# Altium schematic sheet Top_schema.SchDoc
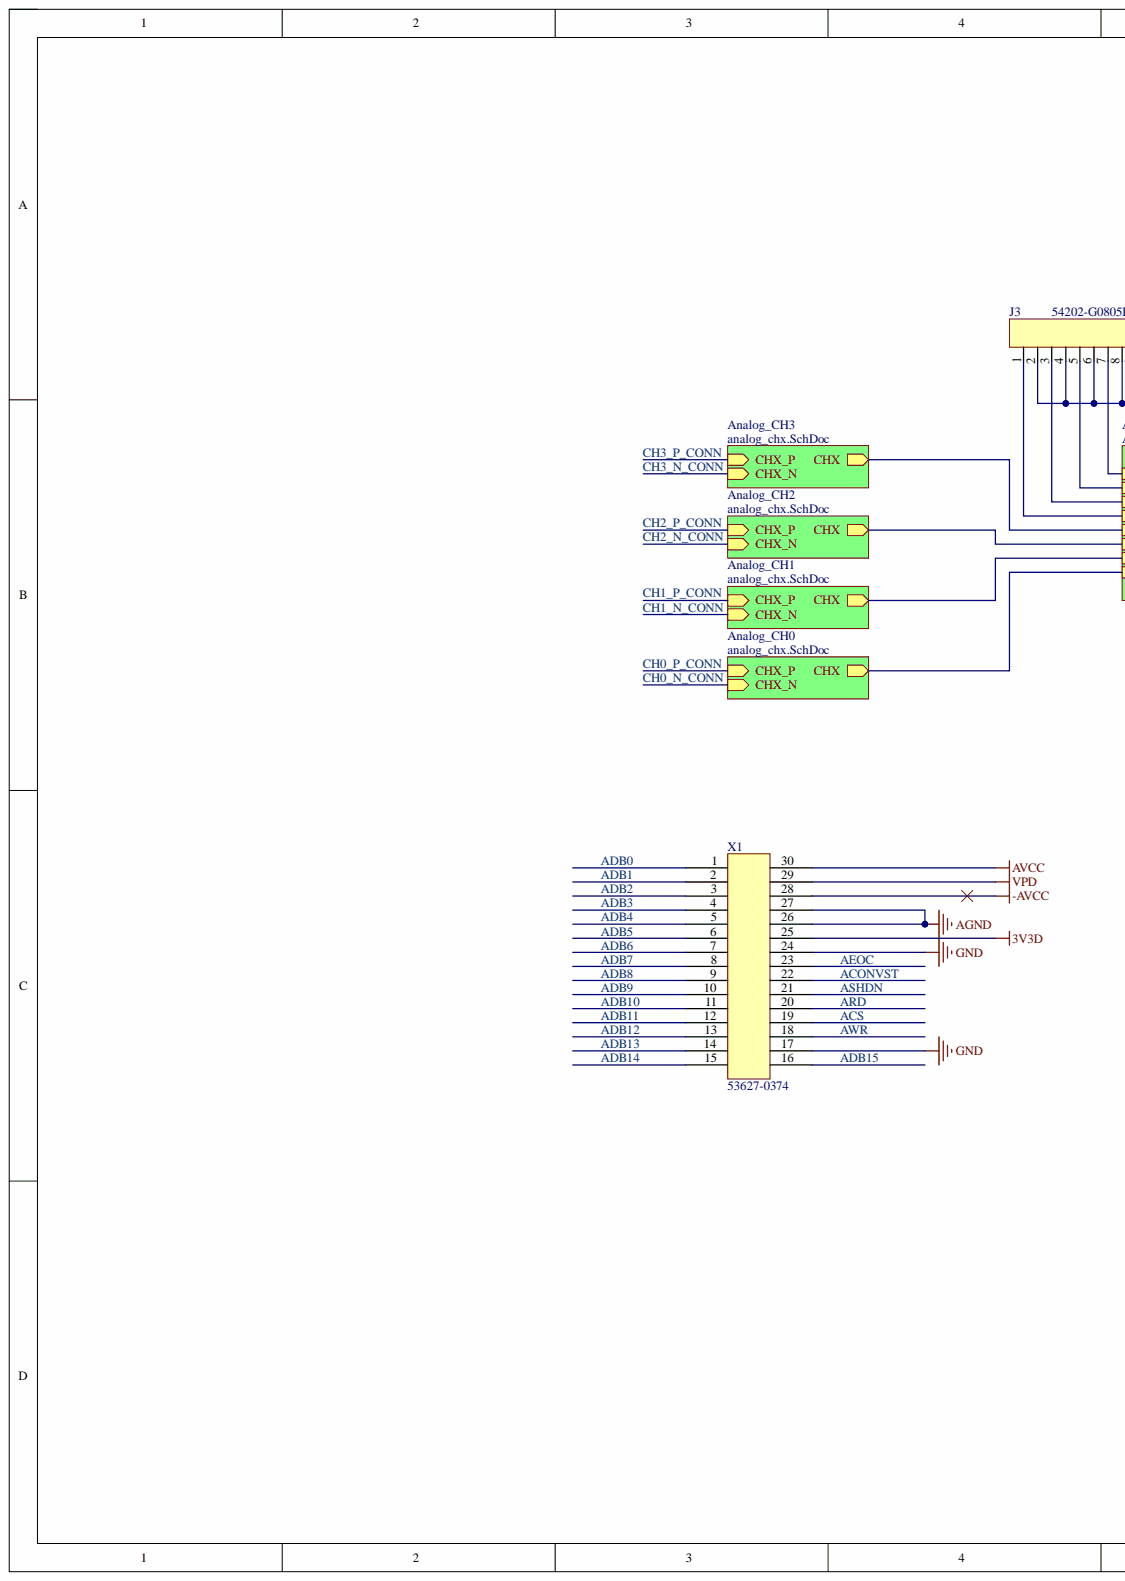
[diagram p0: Top_schema.SchDoc, left]
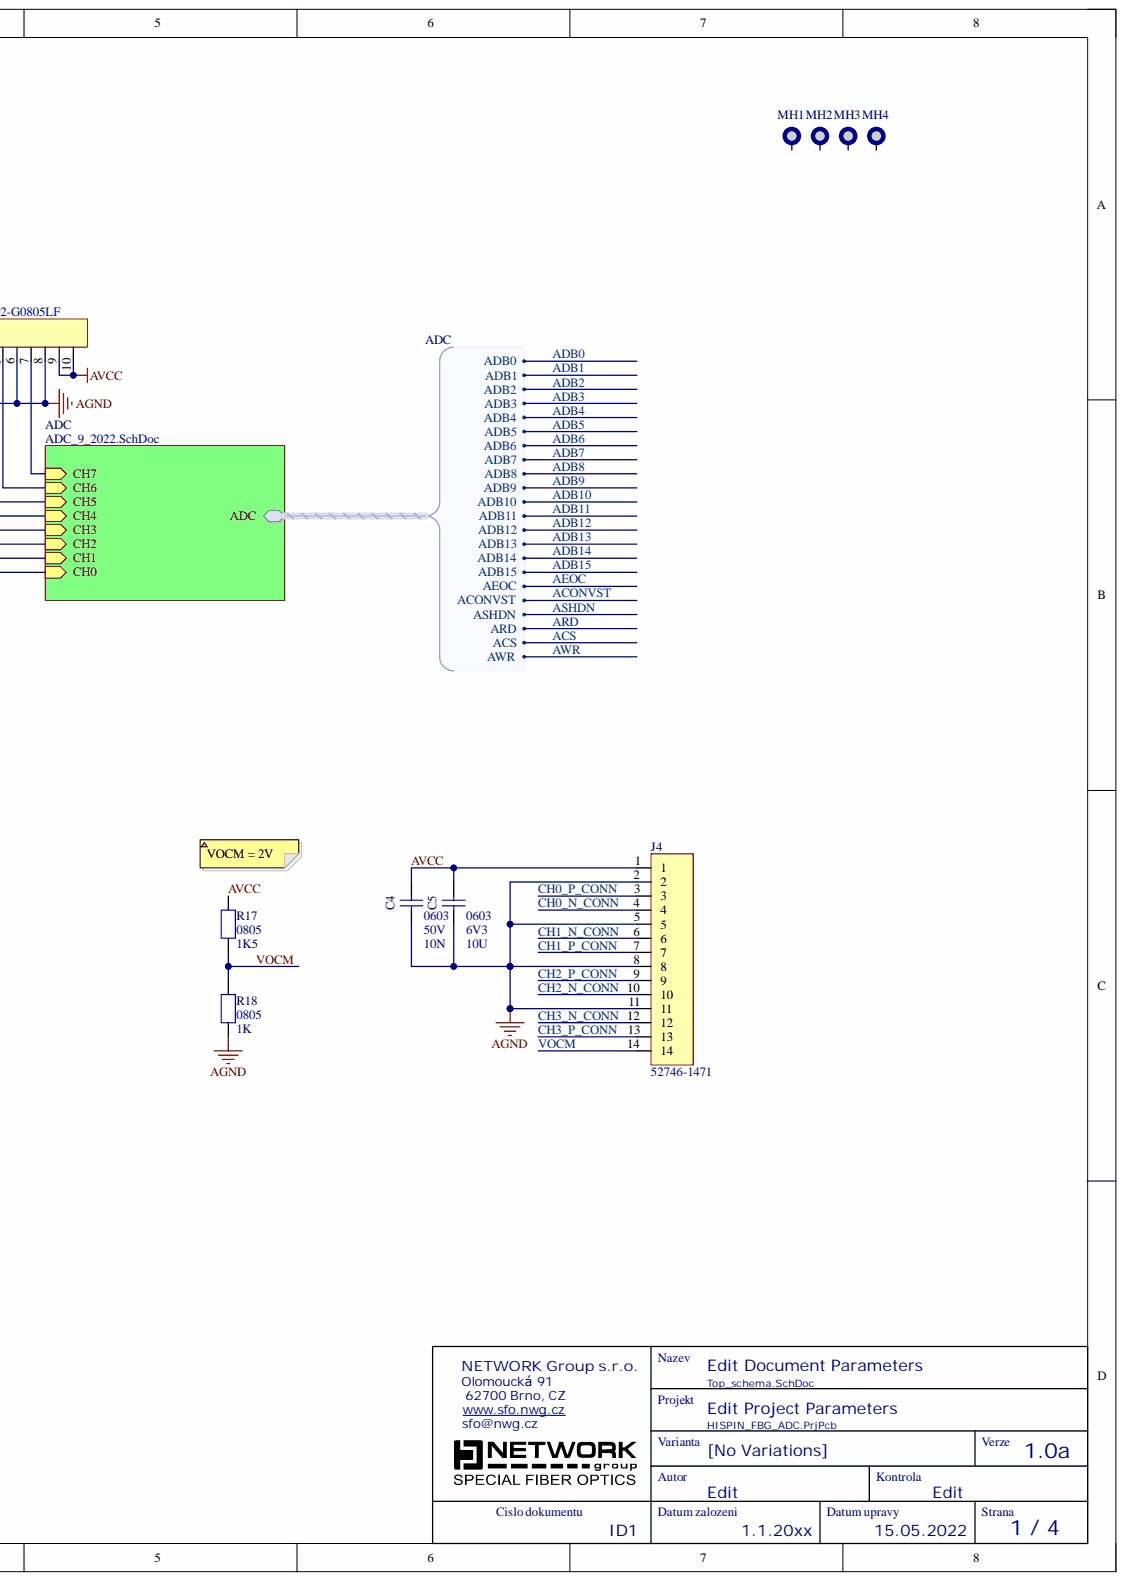
[diagram p1: Top_schema.SchDoc, right]

## Parts
C4  value 10N  Case-EIA: 0603  Related Voltage: 50V  [CAP-0603-M]  (hidden comment: SMD Capacitor 0603 +/-10%)
C5  value 10U  Case-EIA: 0603  Related Voltage: 6V3  [CAP-0603-M]  (hidden comment: SMD Capacitor 0603 +/-20%)
J3  Manufacturer Part Number: 54202-G0805LF  [CON-SMD-2x5 pin]  (hidden comment: Headers & Wire Housings 10P UNSHRD VERT HDR .76 MICROMETER AU)
J4  value 52746-1471  Manufacturer Part Number: 52746-1471  [CONZIF0514M]  (hidden comment: SMD FFC Pitch 0.5mm, 14 Contacts, Receptacle, Contact Position:Bottom, 1 Row Plating:Gold)
MH1  NetTie  [MOUNT-M3]  (value not drawn)
MH2  NetTie  [MOUNT-M3]  (value not drawn)
MH3  NetTie  [MOUNT-M3]  (value not drawn)
MH4  NetTie  [MOUNT-M3]  (value not drawn)
R17  value 1K5  Case-EIA: 0805  [RES0805M]  (hidden comment: SMD Resistor 0805 +/-1%)
R18  value 1K  Case-EIA: 0805  [RES0805M]  (hidden comment: SMD Resistor 0805 +/-0.1%)
X1  value 53627-0374  Manufacturer Part Number: 53627-0374  [MOLEX_536270374_30pin-N]  (hidden comment: Modul board Konektory na vodorovné a svislé propojování desek .635 RECEPTACLE SURFACE MNT 30 CKT)

## Nets
3V3D: X1.25
-AVCC: X1.28
AGND: C4.1 C5.1 J3.2 J3.4 J3.6 J3.8 J4.2 J4.5 J4.8 J4.11 R18.1 X1.26 X1.27
GND: X1.17 X1.24
VPD: X1.29
~{ACS}: X1.19
~{AEOC}: X1.23
~{ARD}: X1.20
~{AWR}: X1.18
ACONVST: X1.22
ADB0: X1.1
ADB1: X1.2
ADB2: X1.3
ADB3: X1.4
ADB4: X1.5
ADB5: X1.6
ADB6: X1.7
ADB7: X1.8
ADB8: X1.9
ADB9: X1.10
ADB10: X1.11
ADB11: X1.12
ADB12: X1.13
ADB13: X1.14
ADB14: X1.15
ADB15: X1.16
ASHDN: X1.21
AVCC: C4.2 C5.2 J3.9 J3.10 J4.1 R17.2 X1.30
CH0_N_CONN: J4.4
CH0_P_CONN: J4.3
CH1_N_CONN: J4.6
CH1_P_CONN: J4.7
CH2_N_CONN: J4.10
CH2_P_CONN: J4.9
CH3_N_CONN: J4.12
CH3_P_CONN: J4.13
VOCM: J4.14 R17.1 R18.2
NetJ3_1: J3.1
NetJ3_3: J3.3
NetJ3_5: J3.5
NetJ3_7: J3.7
NetMH1_MH: MH1.MH
NetMH2_MH: MH2.MH
NetMH3_MH: MH3.MH
NetMH4_MH: MH4.MH
N00001:
N00002:
N00003:
N00004:

## Library data of the parts
C4 = CAP150: Manufacturer: Vishay; Manufacturer Part Number: VJ0603Y103KXBCW1BC; Case-Metric: 1608; Database Table Name: capacitors; Dielectric: X7R; ESR: LOW; Note: I; Polarisation: No; Schematic Drawn by: Josef Elias; Tolerance+: 10; Warehouse: 5; Category: SMD; Component Kind: Standard; ComponentLink1Description: SupplierURL; Description: SMD Capacitor 0603 +/-10%; ID: CAP150; Library Name: Altium_Database.DbLib; Max Thickness: 1mm; RoHS: RoHS; Supplier 1: TME; Supplier Part Number 1: VJ0603Y103KXBCW1BC; Temperature Range+: 85; Temperature Range-: 20; Tolerance-: 10; Footprint Drawn by: Altium IPC footprint
C5 = CAP139: Case-Metric: 1608; Database Table Name: capacitors; Dielectric: X5R; ESR: LOW; Note: I; Polarisation: No; Schematic Drawn by: Josef Elias; Temperature Coeff+: 150000ppm; Tolerance+: 20; Warehouse: 14; Category: SMD; Component Kind: Standard; ComponentLink1Description: SupplierURL; Description: SMD Capacitor 0603 +/-20%; ID: CAP139; Library Name: Altium_Database.DbLib; Max Thickness: 1mm; RoHS: RoHS; Temperature Coeff-: 150000ppm; Temperature Range+: 85; Temperature Range-: 20; Tolerance-: 20; Footprint Drawn by: Altium IPC footprint
J3 = CON0058: Manufacturer: Amphenol FCI; Case-EIA: SMD2X5; Database Table Name: connectors; Note: I; Schematic Drawn by: Lukáš Pizúr; Value: 649-54202-G0805LF; Warehouse: 2; Category: HEADER; Component Kind: Standard; ComponentLink1Description: Datasheet; ComponentLink1URL: https://cz.mouser.com/datasheet/2/18/54202-1362910.pdf; Description: Headers & Wire Housings 10P UNSHRD VERT HDR .76 MICROMETER AU; ID: CON0058; Library Name: Altium_Database.DbLib; Max Thickness: 10mm; RoHS: YES; Status: Active; Supplier 1: Mouser; Supplier Part Number 1: 649-54202-G0805LF; Footprint Drawn by: Lukáš Pizúr
J4 = CON1: Manufacturer: MOLEX; Case-EIA: ZIF0514; Database Table Name: connectors; Note: I; Schematic Drawn by: Josef Elias; Warehouse: 5; Category: ZIF; Component Kind: Standard; ComponentLink1Description: Datasheet; ComponentLink1URL: http://cz.farnell.com/molex/52746-1471/socket-ffc-fpc-zif-0-5mm-14way/dp/1827156; Description: SMD FFC Pitch 0.5mm, 14 Contacts, Receptacle, Contact Position:Bottom, 1 Row Plating:Gold; ID: CON1; Library Name: Altium_Database.DbLib; Max Thickness: 2; RoHS: YES; Status: Active; Supplier 1: FARNELL; Supplier Part Number 1: 1827156; Footprint Drawn by: Altium IPC footprint
MH1-MH4 = MOUNT-M3: Component Kind: Net Tie; Library Name: NoBase.IntLib; Value: MOUNT-M3
R17 = R54: Case-Metric: 2012; Database Table Name: resistors; Note: I; Power: 0.1W; Schematic Drawn by: Josef Elias; Temperature Coeff+: TK 100; Tolerance: 1; Category: RES; Component Kind: Standard; Description: SMD Resistor 0805 +/-1%; ID: R54; Library Name: Altium_Database.DbLib; Max Thickness: 1mm; Status: Active; Temperature Coeff-: TK 100; Temperature max: 80; Temperature min: -40; Footprint Drawn by: Altium IPC footprint
R18 = R224: Manufacturer: Welwyn; Manufacturer Part Number: PCF0805-13-1K-B-T1; Case-Metric: 2012; Database Table Name: resistors; Note: I; Power: 0.1W; Related Voltage: 100V; Schematic Drawn by: Josef Elias; Temperature Coeff+: 100ppm; Tolerance: 0.1; Warehouse: 1; Category: RES; Component Kind: Standard; ComponentLink1URL: http://cz.farnell.com/welwyn/pcf0805-13-1k-b-t1/res-thin-film-1k-0-1-0-1w-0805/dp/1108863; Description: SMD Resistor 0805 +/-0.1%; ID: R224; Library Name: Altium_Database.DbLib; Max Thickness: 1mm; RoHS: Yes; Status: Active; Supplier 1: FARNELL; Supplier Part Number 1: 1108863; Temperature Coeff-: 100ppm; Temperature max: 155; Temperature min: -55; Footprint Drawn by: Altium IPC footprint
X1 = CON0072: Modul board  Konektory na vodorovné a svislé propojování desek .635 RECEPTACLE SURFACE MNT 30 CKT; Manufacturer: MOLEX; Database Table Name: connectors; Note: I; Schematic Drawn by: Milan Holik; Warehouse: 0; Category: SlimStack; Component Kind: Standard; ComponentLink1Description: Datasheet; ComponentLink1URL: https://cz.mouser.com/datasheet/2/276/3/0536270374_PCB_HEADERS-2846371.pdf; ID: CON0072; Library Name: Altium_Database.DbLib; Max Thickness: 2; RoHS: YES; Status: Active; Supplier 1: Mouser; Supplier Part Number 1: 538-53627-0374; Footprint Drawn by: Milan Holik
